# Altium schematic sheet Power.SchDoc
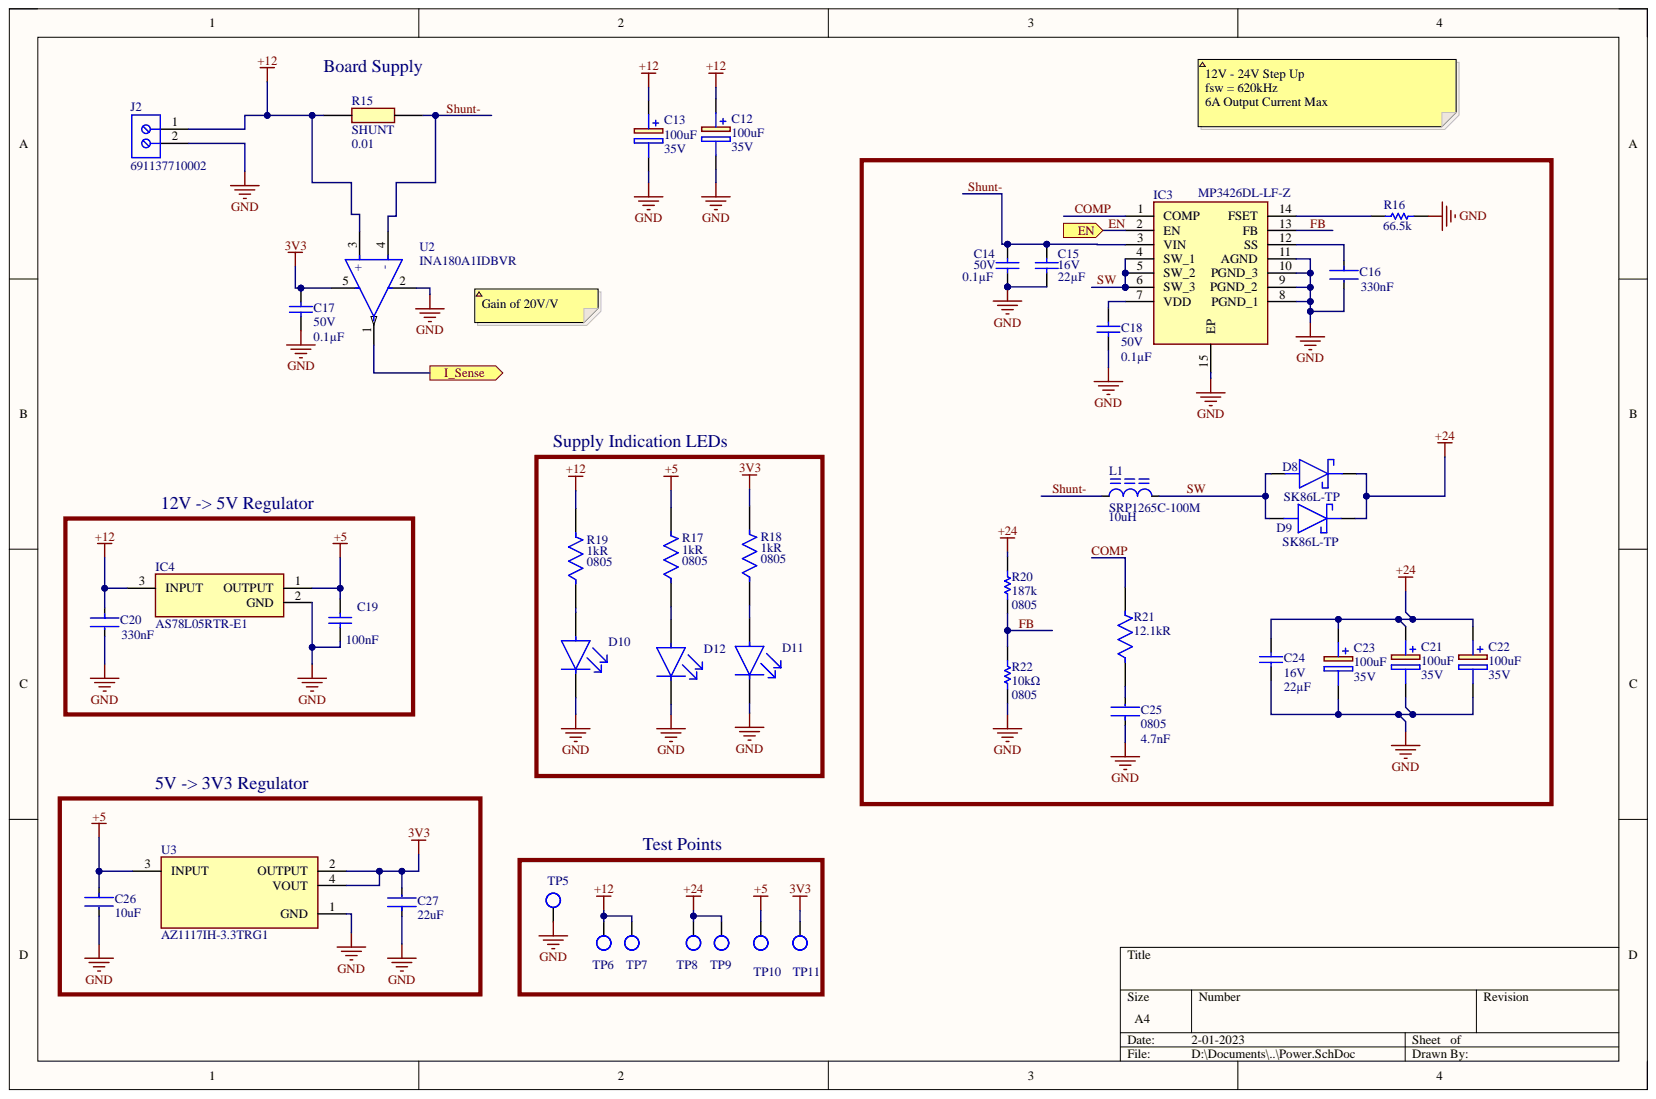
[diagram p0: Power.SchDoc]

## Parts
C12  Capacitance: 100uF  Voltage Rating: 35V  [CAPPRD250W52D630H1250]  (hidden comment: 860010573007)
C13  Capacitance: 100uF  Voltage Rating: 35V  [CAPPRD250W52D630H1250]  (hidden comment: 860010573007)
C14  Capacitance: 0.1µF  Voltage - Rated: 50V  [CAP0805]  (hidden comment: C0805C104K5RACTU)
C15  Capacitance: 22µF  Voltage - Rated: 16V  [0805]  (hidden comment: CL21A226MOCLRNC)
C16  value 330nF  Capacitance: 330nF  [FP-CL21-F-IPC_C]  (hidden comment: CL21B334KBFNNNE)
C17  Capacitance: 0.1µF  Voltage - Rated: 50V  [CAP0805]  (hidden comment: C0805C104K5RACTU)
C18  Capacitance: 0.1µF  Voltage - Rated: 50V  [CAP0805]  (hidden comment: C0805C104K5RACTU)
C19  Capacitance: 100nF  [CAPC2013X140X50ML20T25]  (hidden comment: 08055D104KAT2A)
C20  value 330nF  Capacitance: 330nF  [FP-CL21-F-IPC_C]  (hidden comment: CL21B334KBFNNNE)
C21  Capacitance: 100uF  Voltage Rating: 35V  [CAPPRD250W52D630H1250]  (hidden comment: 860010573007)
C22  Capacitance: 100uF  Voltage Rating: 35V  [CAPPRD250W52D630H1250]  (hidden comment: 860010573007)
C23  Capacitance: 100uF  Voltage Rating: 35V  [CAPPRD250W52D630H1250]  (hidden comment: 860010573007)
C24  Capacitance: 22µF  Voltage - Rated: 16V  [0805]  (hidden comment: CL21A226MOCLRNC)
C25  Capacitance: 4.7nF  Case Code (Imperial): 0805  [FP-CL21-IPC_A]  (hidden comment: CL21B472KBANNNC)
C26  Capacitance: 10uF  [FP-CL21-IPC_B]  (hidden comment: CL21A106KOQNNNE)
C27  Capacitance: 22uF  [FP-CL21-IPC_B]  (hidden comment: CL21A226MQQNNNG)
D8  SK86L-TP  [DIOM7959X262N]
D9  SK86L-TP  [DIOM7959X262N]
D10  150060VS75000  [FP-150060VS75000-MFG]  (value not drawn)
D11  150060VS75000  [FP-150060VS75000-MFG]  (value not drawn)
D12  150060VS75000  [FP-150060VS75000-MFG]  (value not drawn)
IC3  MP3426DL-LF-Z  [SON50P300X400X100-15N-D]
IC4  AS78L05RTR-E1  [DIODES_SOT-89]
J2  691137710002  [691137710002]
L1  SRP1265C-100M  Inductance: 10uH  [FP-SRP1265C-MFG]
R15  SHUNT  Resistance: 0.01  [RESC6434X90N]
R16  Resistance: 66.5k  [RESC2013X70X40LL20T20]  (hidden comment: ERJ-6ENF6652V)
R17  Case Code (Imperial): 0805  Resistance: 1kR  [FP-RNCP0805-MFG]  (hidden comment: RNCP0805FTD1K00)
R18  Case Code (Imperial): 0805  Resistance: 1kR  [FP-RNCP0805-MFG]  (hidden comment: RNCP0805FTD1K00)
R19  Case Code (Imperial): 0805  Resistance: 1kR  [FP-RNCP0805-MFG]  (hidden comment: RNCP0805FTD1K00)
R20  Package: 0805  Resistance: 187k  [RESC2013X70X40NL20T20]  (hidden comment: ERJ-6ENF1873V)
R21  Resistance: 12.1kR  [FP-RNCP0805-IPC_B]  (hidden comment: RNCP0805FTD12K1)
R22  Package / Case: 0805  Resistance: 10kΩ  [RES0805]  (hidden comment: RC0805FR-0710KL)
TP5  GND  [TESTPOINT_LOOP]
TP6  12V  [TESTPOINT_LOOP]  (value not drawn)
TP7  12V  [TESTPOINT_LOOP]  (value not drawn)
TP8  24V  [TESTPOINT_LOOP]  (value not drawn)
TP9  24V  [TESTPOINT_LOOP]  (value not drawn)
TP10  5V  [TESTPOINT_LOOP]  (value not drawn)
TP11  3V3  [TESTPOINT_LOOP]
U2  INA180A1IDBVR  [FP-DBV0005A-IPC_A]
U3  AZ1117IH-3.3TRG1  [FP-SOT223-IPC_B]

## Ports
EN, I_Sense

## Nets
3V3: C17.2 C27.2 R18.2 TP11.1 U2.5 U3.2(OUTPUT) U3.4(VOUT)
+5: C19.2 C26.1 IC4.1 R17.2 TP10.1 U3.3(INPUT)
+12: C12.1 C13.1 C20.1 IC4.3 J2.1 R15.1 R19.2 TP6.1 TP7.1 U2.3(+)
+24: C21.1 C22.1 C23.1 C24.2 D8.1 D9.1 R20.1 TP8.1 TP9.1
GND: C12.2 C13.2 C14.1 C15.1 C16.2 C17.1 C18.1 C19.1 C20.2 C21.2 C22.2 C23.2 C24.1 C25.1 C26.2 C27.1 D10.1 D11.1 D12.1 IC3.8(PGND_1) IC3.9(PGND_2) IC3.10(PGND_3) IC3.11(AGND) IC3.15(EP) IC4.2 J2.2 R16.1 R22.1 TP5.1 U2.2 U3.1(GND)
COMP: IC3.1(COMP) R21.2
EN: IC3.2(EN) ; port EN
FB: IC3.13(FB) R20.2 R22.2
I_Sense: U2.1 ; port I_Sense
Shunt-: C14.2 C15.2 IC3.3(VIN) L1.1 R15.2 U2.4(-)
SW: D8.2 D9.2 IC3.4(SW_1) IC3.5(SW_2) IC3.6(SW_3) L1.2
NetC16_1: C16.1 IC3.12(SS)
NetC18_2: C18.2 IC3.7(VDD)
NetC25_2: C25.2 R21.1
NetD10_2: D10.2 R19.1
NetD11_2: D11.2 R18.1
NetD12_2: D12.2 R17.1
NetIC3_14: IC3.14(FSET) R16.2

## Library data of the parts
C12 C13 C21-C23 = 860010573007: Capacitor Polarised; Manufacturer_Name: Wurth Elektronik; Component Kind: Standard; Datasheet Link: http://katalog.we-online.de/pbs/datasheet/860010573007.pdf; Height: 12.5mm; Manufacturer_Part_Number: 860010573007; Mouser Part Number: 710-860010573007; Mouser Price/Stock: https://www.mouser.co.uk/ProductDetail/Wurth-Elektronik/860010573007?qs=0KOYDY2FL2%2FkmR1S6v%2F3Lg%3D%3D
C14 C17 C18 = C0805C104K5RACTU: CAP CER 0.1UF 50V X7R 0805; Tolerance: ±10%; Component Kind: Standard; Library Name: Capacitors.IntLib; Package / Case: 0805; Supplier 1: Digi-Key; Supplier Part Number 1: 399-1170-1-ND; Temperature Coefficient: X7R
C15 C24 = CL21A226MOCLRNC: CAP CER 22UF 16V X5R 0805; Tolerance: ±20%; Component Kind: Standard; Library Name: Capacitors.IntLib; Supplier 1: Digi-Key; Supplier Part Number 1: 1276-6780-1-ND; Temperature Coefficient: X5R
C16 C20 = CMP-13271-000003-1: CAP CER 0.33UF 50V X7R 0805; Manufacturer: Samsung Electro-Mechanics; Material: Ceramic; Temperature Coefficient: 15%; Tolerance: 10%; Automotive: No; Category: Cap; Component Kind: Standard; ComponentLink2Description: Datasheet; ComponentLink2URL: http://www.samsungsem.com/kr/support/product-search/mlcc/__icsFiles/afieldfile/2018/07/24/CL21B334KBFNNNE.pdf; Device Class L1: Passive Components; Device Class L2: Capacitors; Device Class L3: Ceramic Capacitors; Digikey Description: CAP CER 0.33UF 50V X7R 0805; Height: 1.35mm; Ipc Land Pattern Name: CAPC200125X125; Lead Free: Yes; Library Name: Altium Content Vault; Manufacturer Part Number: CL21B334KBFNNNE; Octopart: https://octopart.com/cl21b334kbfnnne-samsung-9287149; Package: CL21-F; RoHS: TRUE; Temperature: -55°C to +125°C; Temperature Characteristic: X7R; Temperature Range High: +125°C; Temperature Range Low: -55°C; Voltage Rating: 50V
C19 = CMP-2007-04689-1: Manufacturer: AVX Interconnect / Elco; Component Kind: Standard; Library Name: Altium Content Vault; Part Number: 08055D104KAT2A
C25 = CMP-13271-001405-1: CL21 Series 0805 4.7nF 50V ±10% Tolerance X7R Multilayer Ceramic Chip Capacitor; Case Code (Metric): 2012; Case/Package: 0805; Component Kind: Standard; Contact Plating: Tin; Depth: 1.25mm; Dielectric: X7R; Height: 0.65mm; Lead Free: Lead Free; Length: 2mm; Library Name: Altium Content Vault; Material: Ceramic; Max Operating Temperature: 125°C; Min Operating Temperature: -55°C; Mount: Surface Mount; Package Quantity: 1; Packaging: Tape and Reel; Radiation Hardening: No; Thickness: 0.03inch; Tolerance: 10%; Voltage: 50V; Voltage Rating: 50V; Voltage Rating (DC): 50V; Width: 0.049inch
C26 = CMP-2000-06102-2: CL21 Series 0805 10uF 16V ±10% Tolerance X5R Multilayer Ceramic Chip Capacitor; Dielectric: X7R; Case Code (Imperial): 0805; Case Code (Metric): 2012; Case/Package: 0805; Component Kind: Standard; Depth: 1.25mm; Height: 1.25mm; Lead Free: Lead Free; Length: 2mm; Library Name: Altium Content Vault; Max Operating Temperature: 85°C; Min Operating Temperature: -55°C; Mount: Surface Mount; Package Quantity: 1; Packaging: Tape and Reel; Radiation Hardening: No; Thickness: 0.055inch; Tolerance: 10%; Voltage: 16V; Voltage Rating: 16V; Voltage Rating (DC): 16V; Width: 0.049inch
C27 = CMP-13271-002967-1: CL21 Series 0805 22uF 6.3V ±20% Tolerance X5R Multilayer Ceramic Chip Capacitor; Case Code (Imperial): 0805; Case Code (Metric): 2012; Case/Package: 0805; Component Kind: Standard; Depth: 1.25mm; Dielectric: X5R; Height: 1.25mm; Length: 2mm; Library Name: Altium Content Vault; Material: Ceramic; Max Operating Temperature: 85°C; Min Operating Temperature: -55°C; Mount: Surface Mount; Package Quantity: 3000; Packaging: Tape and Reel; Radiation Hardening: No; Thickness: 0.055inch; Tolerance: 20%; Voltage: 6.3V; Voltage Rating: 6.3V; Width: 0.049inch
D8 D9 = SK86L-TP: Schottky Diode; Manufacturer_Name: Micro Commercial Components (MCC); Arrow Part Number: SK86L-TP; Arrow Price/Stock: https://www.arrow.com/en/products/sk86l-tp/micro-commercial-components?region=nac; Component Kind: Standard; Datasheet Link: https://www.mccsemi.com/pdf/Products/SK82L-SK810L(SMC).PDF; Height: 2.62mm; Manufacturer_Part_Number: SK86L-TP; Mouser Part Number: 833-SK86L-TP; Mouser Price/Stock: https://www.mouser.co.uk/ProductDetail/Micro-Commercial-Components-MCC/SK86L-TP?qs=Fllw7YelV3%2FmZPZoVB2kyw%3D%3D
D10-D12 = CMP-1426-00006-5: LED GREEN CLEAR 0603 SMD; Dominant Wavelength: 570nm; Forward Current: 20mA; Forward Voltage: 2V; Lens Color: Colorless; Lens Size: 0.7mm; Lens Style: Rectangular; Luminous Intensity: 40mcd; Power Rating: 72mW; Reverse Voltage (DC): 5V; Viewing Angle: 140°; Case Code (Imperial): 0603; Case Code (Metric): 1608; Case/Package: 0603; Color: Green; Component Kind: Standard; Height: 0.8mm; Illumination Color: Green; Length: 1.6mm; Lens Transparency: Clear; Library Name: Altium Content Vault; Max Operating Temperature: 85°C; Min Operating Temperature: -40°C; Mount: Surface Mount; Number of Pins: 2; Package Quantity: 4000; Packaging: Cut Tape; RoHS Compliant: Yes; Series: WL-SMCW; Test Current: 20mA; Wavelength: 570nm; Weight: 0.000882oz; Width: 0.8mm
IC3 = MP3426DL-LF-Z: Integrated Circuit; Manufacturer_Name: Monolithic Power Systems (MPS); Component Kind: Standard; Datasheet Link: https://www.monolithicpower.com/en/documentview/productdocument/index/version/2/document_type/Datasheet/lang/en/sku/MP3426/; Height: 1mm; Manufacturer_Part_Number: MP3426DL-LF-Z; Mouser Part Number: 946-MP3426DL-LF-Z; Mouser Price/Stock: https://www.mouser.co.uk/ProductDetail/Monolithic-Power-Systems-MPS/MP3426DL-LF-Z?qs=Z3CaLxJiOJL0MxCuEKYvhQ%3D%3D
IC4 = AS78L05RTR-E1: Integrated Circuit; Manufacturer_Name: Diodes Inc.; Arrow Part Number: AS78L05RTR-E1; Arrow Price/Stock: https://www.arrow.com/en/products/as78l05rtr-e1/diodes-incorporated?region=asia; Component Kind: Standard; Datasheet Link: https://www.diodes.com/assets/Datasheets/AS78Lxx.pdf; Manufacturer_Part_Number: AS78L05RTR-E1; Mouser Part Number: 621-AS78L05RTR-E1; Mouser Price/Stock: https://www.mouser.co.uk/ProductDetail/Diodes-Incorporated/AS78L05RTR-E1?qs=FKu9oBikfSksfBIasZ7FtQ%3D%3D; Library Name: WPT_Transmitter_lib.SchLib
J2 = CMP-1502-03324-1: Series 1377 - 5.00 mm Horizontal Entry with Pressure Clamp WR-TBL, 2 pin; Manufacturer: Wurth Elektronik; Pin Number: 2; Pitch: 5.00mm; Component Kind: Standard; Datasheet URL: http://katalog.we-online.de/pbs/datasheet/691137710002.pdf; Library Name: Altium Content Vault; Manufacturer URL: http://www.we-online.de; Mounting Technology: THT; Operating Temperature: -30to120degC; Package Reference: 691137710002; Packaging: Box; Part Number: 691137710002; Publisher: WurthElektronik
L1 = CMP-07248-000045-1: FIXED IND 10UH 12A 18 MOHM SMD; Component Kind: Standard; Library Name: Altium Content Vault; Part Number: SRP1265C-100M
R15 = CRA2512-FZ-R010ELF: Resistor; Manufacturer_Name: Bourns; Arrow Part Number: CRA2512-FZ-R010ELF; Arrow Price/Stock: https://www.arrow.com/en/products/cra2512-fz-r010elf/bourns; Component Kind: Standard; Datasheet Link: http://www.bourns.com/PDFs/CRA.pdf; Height: 0.9mm; Manufacturer_Part_Number: CRA2512-FZ-R010ELF; Mouser Part Number: 652-CRA2512FZR010ELF; Mouser Price/Stock: https://www.mouser.co.uk/ProductDetail/Bourns/CRA2512-FZ-R010ELF?qs=WqWCsLCZBkoNPiv%2FXVnYvw%3D%3D
R16 = CMP-2001-00269-1: Manufacturer: Panasonic; Component Kind: Standard; Library Name: Altium Content Vault; Part Number: ERJ-6ENF6652V
R17-R19 = CMP-26527-001902-1: 1kΩ ±1% 0.25W 0805 High Power Anti-Sulfur Thin Film Chip Resistor; Max Power Dissipation: 250mW; Temperature Coefficient: 100ppm/°C; Case Code (Metric): 2012; Case/Package: 0805; Component Kind: Standard; Features: Moisture Resistant; Height: 0.024inch; Lead Free: Lead Free; Length: 0.079inch; Library Name: Altium Content Vault; Max Operating Temperature: 155°C; Min Operating Temperature: -55°C; Mount: Surface Mount; Number of Terminations: 2; Packaging: Tape and Reel; Power Rating: 250mW; Resistor Type: Thin Film; RoHS Compliant: Yes; Series: RNCP; Size Code: 0805; Tolerance: 1%; Width: 0.049inch
R20 = CMP-1013-00765-2: Manufacturer: Panasonic; Component Kind: Standard; Library Name: Altium Content Vault; Part Number: ERJ-6ENF1873V
R21 = CMP-26527-004331-1: 12.1kΩ ±1% 0.25W 0805 High Power Anti-Sulfur Thin Film Chip Resistor; Max Power Dissipation: 250mW; Temperature Coefficient: 100ppm/°C; Case Code (Imperial): 0805; Case Code (Metric): 2012; Case/Package: 0805; Component Kind: Standard; Features: Moisture Resistant; Height: 0.024inch; Length: 0.079inch; Library Name: Altium Content Vault; Max Operating Temperature: 155°C; Min Operating Temperature: -55°C; Mount: Surface Mount; Number of Terminations: 2; Packaging: Tape and Reel; Resistor Type: Thin Film; RoHS Compliant: Yes; Series: RNCP; Tolerance: 1%; Width: 0.049inch
R22 = RC0805FR-0710KL: RES SMD 10K OHM 1% 1/8W 0805; Manufacturer: Yageo; Power (Watts): 0.125W, 1/8W; Temperature Coefficient: ±100ppm/°C; Component Kind: Standard; Composition: Thick Film; Features: Moisture Resistant; Library Name: Resistors.IntLib; Operating Temperature: -55°C ~ 155°C; Supplier 1: Digi-Key; Supplier Part Number 1: 311-10.0KCRCT-ND; Tolerance: ±1%
TP5-TP11 = TESTPOINT_LOOP: PC TEST POINT MINIATURE WHITE; Manufacturer 1: Keystone Electronics; Manufacturer Part Number 1: 5002; Component Kind: Standard; Library Name: Connectors and Headers.IntLib; Mount: Through Hole; Supplier 1: Digi-Key; Supplier Part Number 1: 36-5002-ND
U2 = CMP-04895-000140-1: IC CURR SENSE 1 CIRCUIT SOT23-5; -3db Bandwidth: 350kHz; Input Bias Current: 100nA; Input Offset Voltage (Vos): 100uV; Number of Circuits: 1; Operating Supply Current: 260uA; Slew Rate: 2V/µs; Case/Package: SOT-23-5; Component Kind: Standard; Library Name: Altium Content Vault; Max Operating Temperature: 125°C; Min Operating Temperature: -40°C; Mount: Surface Mount; Packaging: Tape and Reel
U3 = CMP-12756-000043-1: IC REG LINEAR 3.3V 1A SOT223; Dropout Voltage: 1.2V; Number of Regulators: 1; Output Current: 800mA; Case/Package: TO-261-4; Component Kind: Standard; Library Name: Altium Content Vault; Max Operating Temperature: 125°C; Min Current Limit: 1A; Min Operating Temperature: -40°C; Mount: Surface Mount; Number of Pins: 3; Output Voltage: 3.3V; Packaging: Tape and Reel; RoHS Compliant: Yes
